# Altium schematic sheet EIT_PWR_Alternate_2.SchDoc
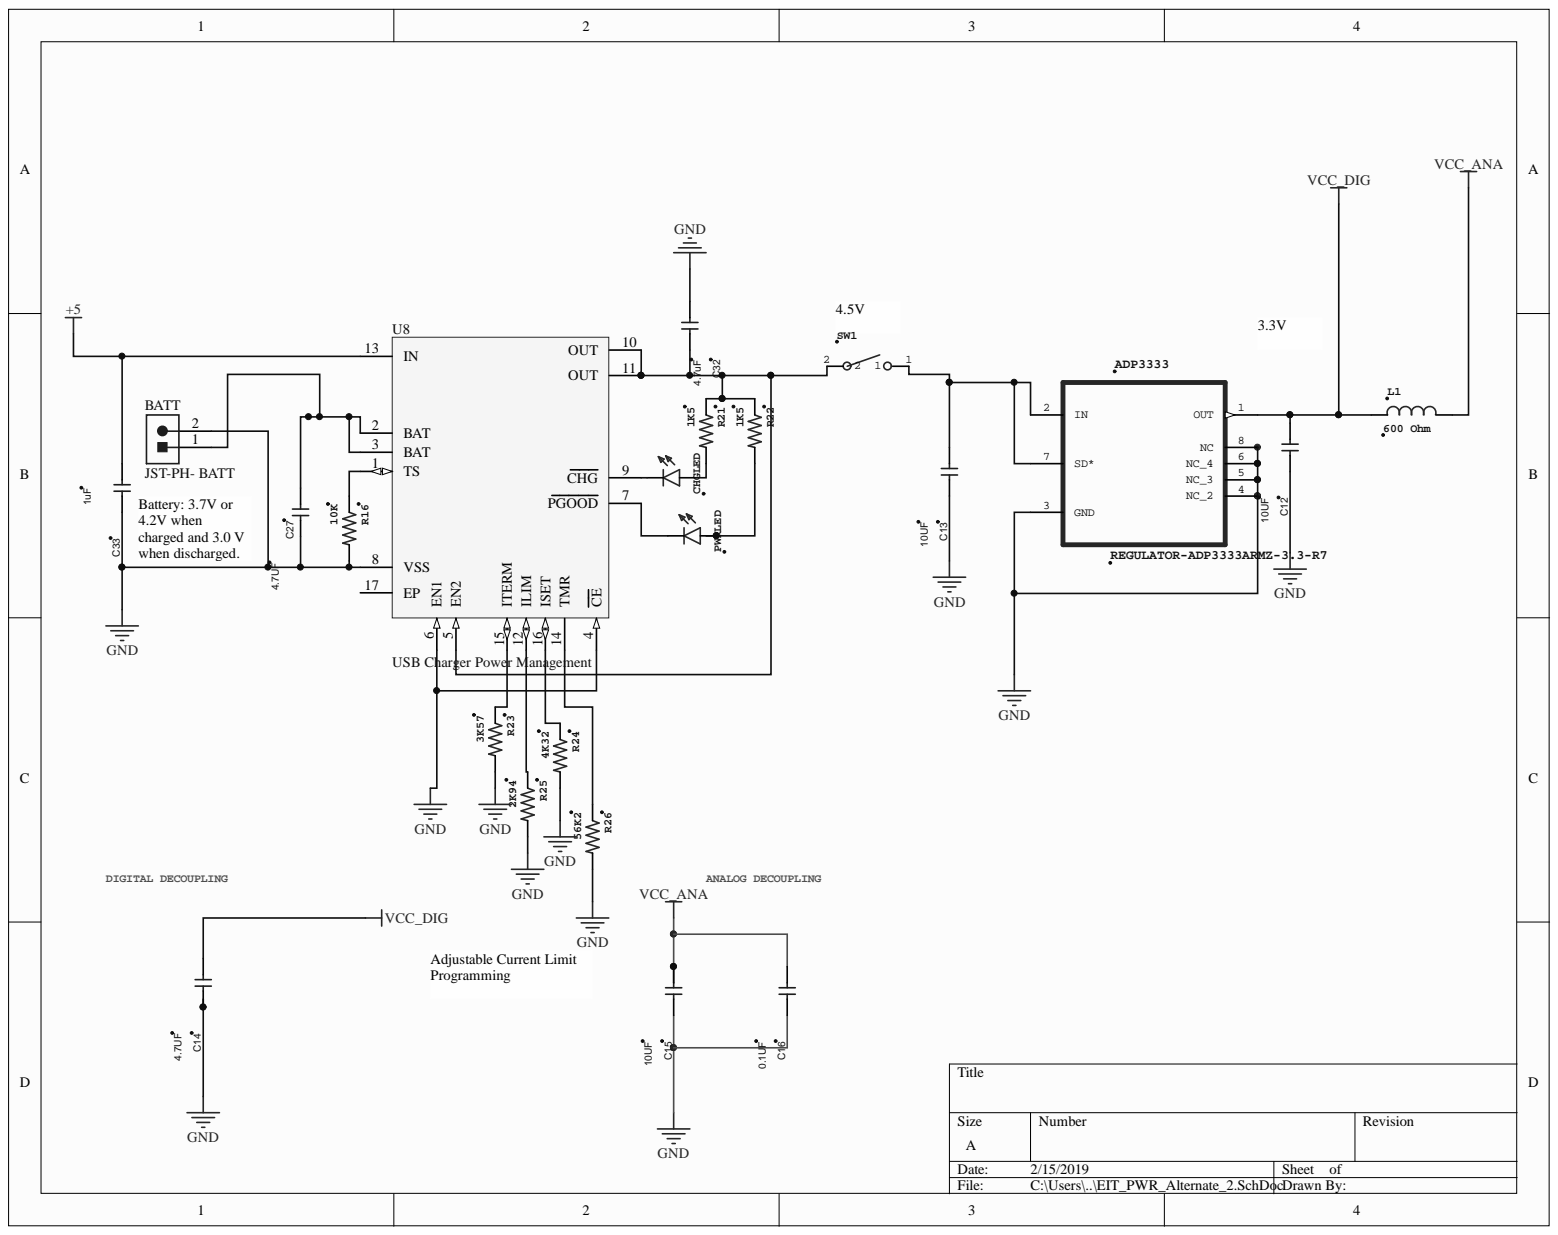
[diagram p0: EIT_PWR_Alternate_2.SchDoc]

## Parts
ADP3333  REGULATOR-ADP3333ARMZ-3.3-R7  [ADP-3333 Regulator]
BATT  JST-PH- BATT  [JST-S2B-PH-K-S_V]
C12  10UF  [CAP 0402/1005]
C13  10UF  [CAP 0402/1005]
C14  4.7UF  [CAP 0402/1005]
C15  10UF  [CAP 0402/1005]
C16  0.1UF  [CAP 0402/1005]
C27  4.7UF  [CAP 0402/1005]
C32  4.7uF  [CAP_0603]
C33  1uF  [CAP_0603]
CHGLED  LED-Red 0603  [0603_LED]  (value not drawn)
L1  600 Ohm  value 600  [Inductor_0603]
PWRLED  LED-Blue 0603  [0603_LED]  (value not drawn)
R16  10K  [RES_1608X55]
R21  1K5  [RES_1608X55]
R22  1K5  [RES_1608X55]
R23  3K57  [RES_1608X55]
R24  4K32  [RES_1608X55]
R25  2K94  [RES_1608X55]
R26  56K2  [RES_1608X55]
SW1  SWITCH-SPST  [SWITCH]  (value not drawn)
U8  USB Charger Power Management  [RGT16-1700X1700TP]

## Nets
+5: C33.2 U8.13(IN)
GND: ADP3333.3(GND) ADP3333.4(NC_2) ADP3333.5(NC_3) ADP3333.6(NC_4) ADP3333.8(NC) BATT.2 C12.1 C13.1 C14.1 C15.1 C16.1 C27.1 C32.1 C33.1 R16.1 R23.1 R24.1 R25.1 R26.1 U8.4(CE) U8.6(EN1) U8.8(VSS)
VCC_ANA: C15.2 C16.2 L1.2
VCC_DIG: ADP3333.1(OUT) C12.2 C14.2 L1.1
NetADP3333_2: ADP3333.2(IN) ADP3333.7(SD*) C13.2 SW1.1
NetBATT_1: BATT.1 C27.2 U8.2(BAT) U8.3(BAT)
NetC32_2: C32.2 R21.2 R22.2 SW1.2 U8.5(EN2) U8.10(OUT) U8.11(OUT)
NetCHGLED_A: CHGLED.A R21.1
NetCHGLED_C: CHGLED.C U8.9(CHG)
NetPWRLED_A: PWRLED.A R22.1
NetPWRLED_C: PWRLED.C U8.7(PGOOD)
NetR16_2: R16.2 U8.1(TS)
NetR23_2: R23.2 U8.15(ITERM)
NetR24_2: R24.2 U8.16(ISET)
NetR25_2: R25.2 U8.12(ILIM)
NetR26_2: R26.2 U8.14(TMR)

Not connected: U8 17(EP)

## Library data of the parts
ADP3333 = REGULATOR-ADP3333ARMZ-3.3-R7: High Accuracy Ultralow IQ, 300 mA, anyCAP  Low Dropout Regulator; Manufacturer 1: Analog Devices; GateName_1: A; Component Kind: Standard; DeviceSetName: REGULATOR-ADP3333ARMZ-3.3-R7; Manufacturer Part Number 1: ADP3333ARMZ-3.3-R7; OC_FARNELL: 2074872; OC_NEWARK: 67W2712; PACKAGE: MSOP-8; Supplier 1: Newark; Supplier 2: Digi-Key; Supplier Part Number 1: 76T1765; Supplier Part Number 2: ADP3333ARMZ-3.3-R7DKR-ND; SymbolName_1: ADP3333ARMZ-3.3-R7; Library Name: EIT_lib.SchLib; LibraryName: BiomedicalImagingLibrary
BATT = JST-PH-BATT: Male Header, Pitch 2 mm, 1 x 2 Position, Height 4.8 mm, Tail Length 3.4 mm, -25 to 85 degC, RoHS, Bulk; Manufacturer 1: JST Sales America; Manufacturer Part Number 1: S2B-PH-K-S(LF)(SN); Capacitance: 10 uF; Case\Package: Radial; Tolerance: 20%; Voltage Rating: 50 V; Component Kind: Standard; ComponentLink1Description: Datasheet; ComponentLink1URL: http://www.jst-mfg.com/product/pdf/eng/ePH.pdf; DatasheetVersion: Dec-12; Diameter: 5 mm; Height: 11 mm; Lead Pitch: 2 mm; Length: 11 mm; Max Operating Temperature: 85 degC; Min Operating Temperature: -40 degC; Mount: Through Hole; PackageDescription: Male Header, Pitch 2 mm, 1 x 2 Position, Height 4.8 mm, Tail Length 3.4 mm; Package Quantity: 200; PackageReference: S2B-PH-K-S; Packaging: Bulk; Pins: 2; Radiation Hardening: No; REACH SVHC: No SVHC; RoHSCompliant: true; Supplier 1: Digi-Key; Supplier Part Number 1: 455-1719-ND; Voltage Rating (DC): 50 V; Library Name: EIT_lib.SchLib
C12 C13 C15 = CAP_0402_10UF: CAP CER 10UF 100V C0G 0402; Manufacturer 1: Taiyo Yuden; Manufacturer Part Number 1: AMK105CBJ106MV-F; Vendor: DIGIKEY; Component Kind: Standard; Supplier 1: Digi-Key; Supplier Part Number 1: 587-3237-1-ND; Value: 10UF; Library Name: EIT_lib.SchLib
C14 C27 = CAP_0402_4.7UF: CAP CER 4.7UF 4V X5R 0402; Manufacturer 1: Taiyo Yuden; Manufacturer Part Number 1: GRM155R60G475ME87D; Vendor: DIGIKEY; Component Kind: Standard; Supplier 1: Digi-Key; Supplier Part Number 1: 587-1966-6-ND; Value: 4.7UF; Library Name: EIT_lib.SchLib
C16 = CAP_0402_0.1UF: CAP CER 0.1UF 4V X5R 0402 10% tolerance; Manufacturer 1: KEMET; Manufacturer Part Number 1: C0402C104K7PAC7867; Vendor: DIGIKEY; Component Kind: Standard; Supplier 1: Digi-Key; Supplier Part Number 1: 399-14871-1-ND; Value: 0.1UF; Library Name: EIT_lib.SchLib
C32 = CAP_0603_4.7uF: CAP CER 4.7UF 6.3V 20% X5R 0603; Manufacturer 1: KEMET; Manufacturer Part Number 1: C0603C475K9PACTU; Vendor: DIGIKEY; Component Kind: Standard; Value: 4.7uF; Library Name: EIT_lib.SchLib
C33 = CAP_0603_1uF: CAP CER 1UF 6.3V 20% X5R 0603; Manufacturer 1: Samsung Electromechanics; Manufacturer Part Number 1: CL10A105MQ8NNNC; Vendor: DIGIKEY; VendorPN: 311-1448-1-ND; Component Kind: Standard; Supplier 1: Digi-Key; Supplier Part Number 1: 1276-1867-1-ND; Value: 1uF; Library Name: EIT_lib.SchLib
CHGLED = LED-Red 0603: Red 470nm LED Indication - Discrete 3.2V 0603 (1608 Metric); Manufacturer 1: Wurth Electronics; Manufacturer Part Number 1: 150060SS75000; DeviceName: -0603; GateName_1: G$1; Component Kind: Standard; DeviceSetName: LED; OC_NEWARK: 40K0133; Supplier 1: Newark; Supplier 2: Digi-Key; Supplier Part Number 1: 32M3100; Supplier Part Number 2: 732-4979-1-ND; SymbolName_1: LED; Library Name: EIT_lib.SchLib; LibraryName: BiomedicalImagingLibrary
L1 = INDUCTOR_0603_600: 600 Ohm Inductor 0603; Manufacturer 1: Murata Electronics North America; Manufacturer Part Number 1: BLM18HG601SN1D; DeviceName: -0603; Component Kind: Standard; DeviceSetName: INDUCTOR; Supplier 1: Digi-Key; Supplier Part Number 1: 490-1033-1-ND; SymbolName_1: INDUCTOR; Library Name: EIT_lib.SchLib; LibraryName: BiomedicalImagingLibrary
PWRLED = LED-Blue 0603: Blue 470nm LED Indication - Discrete 3.2V 0603 (1608 Metric); Manufacturer 1: Wurth Electroncis; Manufacturer Part Number 1: 150060BS75000; MPN: HSML-C191; DeviceName: -0603; GateName_1: G$1; Component Kind: Standard; DeviceSetName: LED; OC_NEWARK: 40K0133; Supplier 1: Newark; Supplier 2: Digi-Key; Supplier Part Number 1: 32M3100; Supplier Part Number 2: 732-4966-1-ND; SymbolName_1: LED; Library Name: EIT_lib.SchLib; LibraryName: BiomedicalImagingLibrary
R16 = RES_0603_10K: 10kOhm RES_0603; Manufacturer 1: VISHAY/DALE; Manufacturer Part Number 1: TNPW060310K0BEEA; PARTNO: MCR03ERTF5600; DeviceName: -0603(1608METRIC); DeviceSetName: RESISTOR-US; GateName_1: G$1; Component Kind: Standard; OC_NEWARK: 52K8621; Supplier 1: Digi-Key; Supplier Part Number 1: TNP10.0KAACT-ND; SymbolName_1: RESISTOR-US; Value: 10K; Library Name: EIT_lib.SchLib; LibraryName: BiomedicalImagingLibrary
R21 R22 = RES_0603_1K5: 1k5Ohm RES_0603; Manufacturer 1: Yageo; Manufacturer Part Number 1: RC0603FR-071K5L; DeviceName: -0603(1608METRIC); DeviceSetName: RESISTOR-US; GateName_1: G$1; Component Kind: Standard; Supplier 1: Digi-Key; Supplier Part Number 1: 311-1.50KHRCT-ND; SymbolName_1: RESISTOR-US; Value: 1K5; Library Name: EIT_lib.SchLib
R23 = RES_0603_3K57: 3k57Ohm RES_0603; Manufacturer 1: Yageo; Manufacturer Part Number 1: RC0603FR-073K57L; PARTNO: MCR03ERTF5600; DeviceName: -0603(1608METRIC); DeviceSetName: RESISTOR-US; GateName_1: G$1; Component Kind: Standard; OC_NEWARK: 52K8621; Supplier 1: Digi-Key; Supplier Part Number 1: 311-3.57KHRDKR-ND; SymbolName_1: RESISTOR-US; Value: 3K57; Library Name: EIT_lib.SchLib; LibraryName: BiomedicalImagingLibrary
R24 = RES_0603_4K32: 4k32Ohm RES_0603; Manufacturer 1: Yageo; Manufacturer Part Number 1: RC0603FR-074K32L; DeviceName: -0603(1608METRIC); DeviceSetName: RESISTOR-US; GateName_1: G$1; Component Kind: Standard; Supplier Part Number 1: 311-4.32KHRDKR-ND; SymbolName_1: RESISTOR-US; Value: 4K32; Library Name: EIT_lib.SchLib
R25 = RES_0603_2K94: 2k94Ohm RES_0603; Manufacturer 1: Yageo; Manufacturer Part Number 1: RC0603FR-072K94L; PARTNO: MCR03ERTF5600; DeviceName: -0603(1608METRIC); DeviceSetName: RESISTOR-US; GateName_1: G$1; Component Kind: Standard; OC_NEWARK: 52K8621; Supplier 1: Digi-Key; Supplier Part Number 1: 311-2.94KHRDKR-ND; SymbolName_1: RESISTOR-US; Value: 2K94; Library Name: EIT_lib.SchLib; LibraryName: BiomedicalImagingLibrary
R26 = RES_0603_56K2: 56k2Ohm RES_0603; Manufacturer 1: Yageo; Manufacturer Part Number 1: RC0603FR-0756K2L; DeviceName: -0603(1608METRIC); DeviceSetName: RESISTOR-US; GateName_1: G$1; Component Kind: Standard; Supplier Part Number 1: 311-56.2KHRCT-ND; SymbolName_1: RESISTOR-US; Value: 56K2; Library Name: EIT_lib.SchLib
SW1 = SWITCH-SPST: Manufacturer 1: CW Industries; Manufacturer Part Number 1: G-105-0513; GateName_1: G$1; SymbolName_1: SWITCH-SPDT; Component Kind: Standard; DeviceSetName: SWITCH-SPST; Library Name: EIT_lib.IntLib; LibraryName: BiomedicalImagingLibrary
U8 = BQ24232RGTR: USB Friendly 0.5 A Li+ Charger with Dynamic Power Management, 10.5 V OVP, 4.3 V, -40 to 85 degC, 16-pin QFN (RGT), Green (RoHS & no Sb/Br); Manufacturer 1: Texas Instruments; Vendor: Digi-Key; VendorPN: BQ24232RGTR-ND; Component Kind: Standard; Manufacturer Part Number 1: BQ24232RGTR; Library Name: EIT_lib.SchLib
